# Altium schematic sheet Breathalyzer.SchDoc(Breathalyzer)
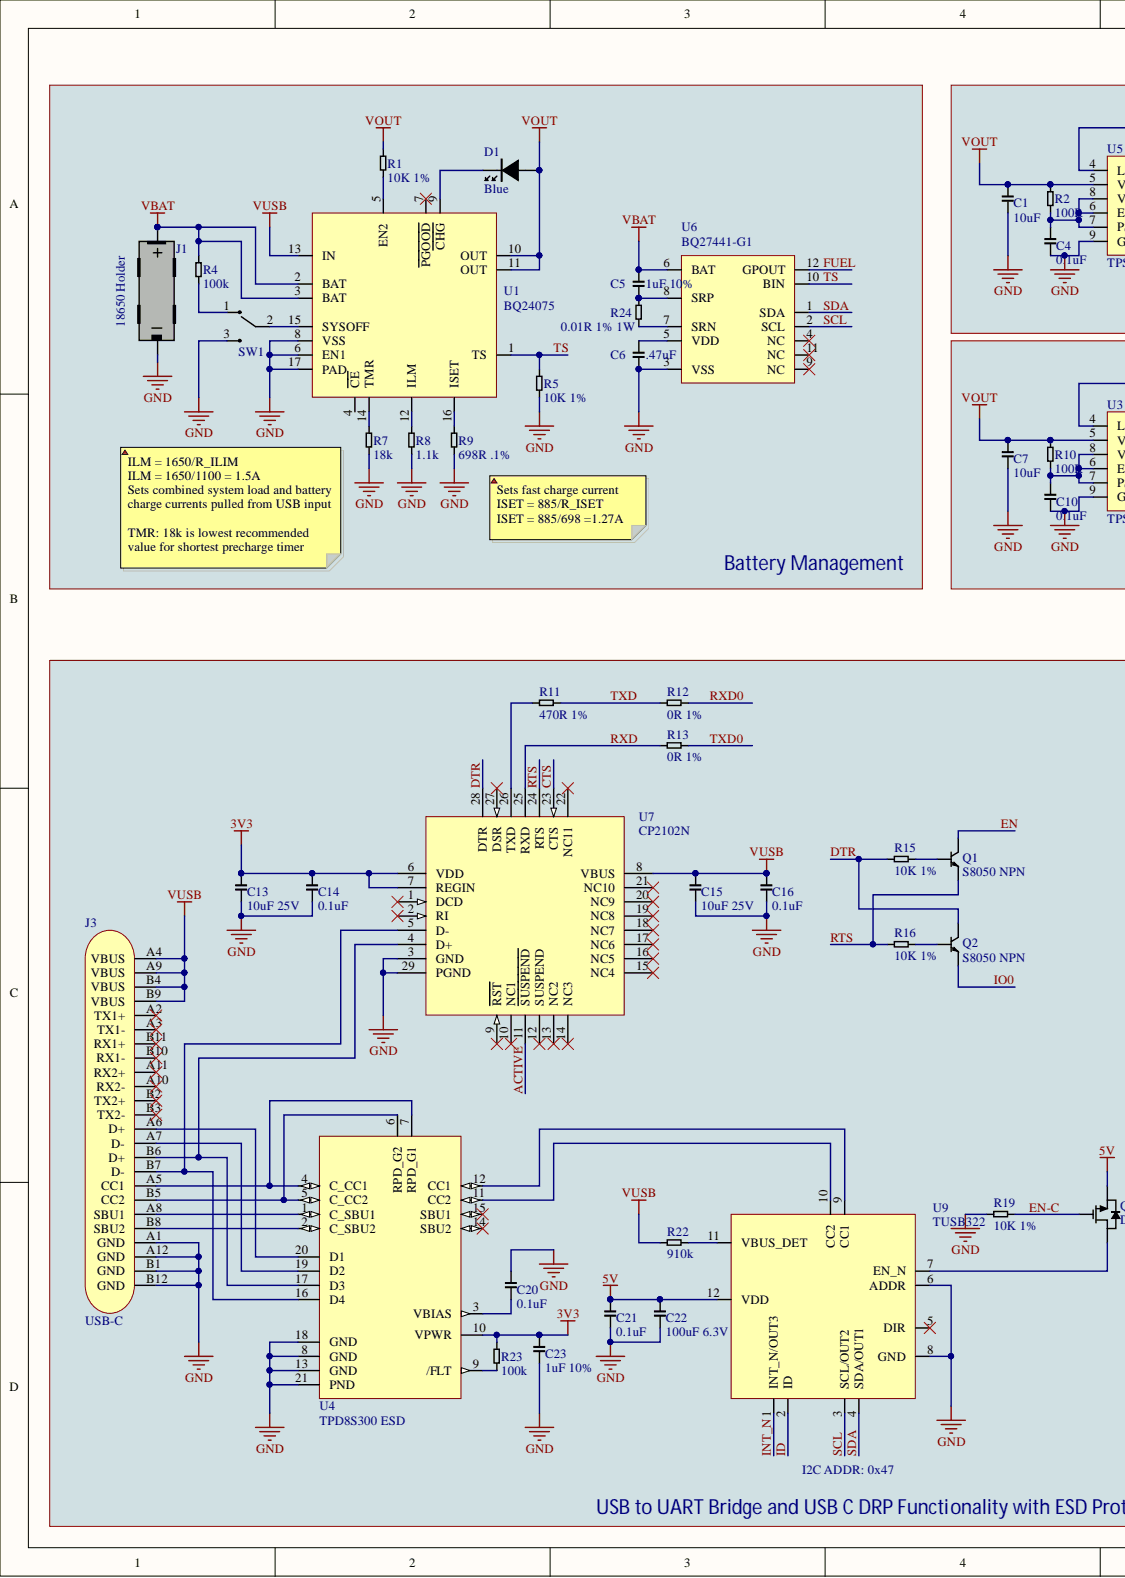
[diagram p0: Breathalyzer.SchDoc, left]
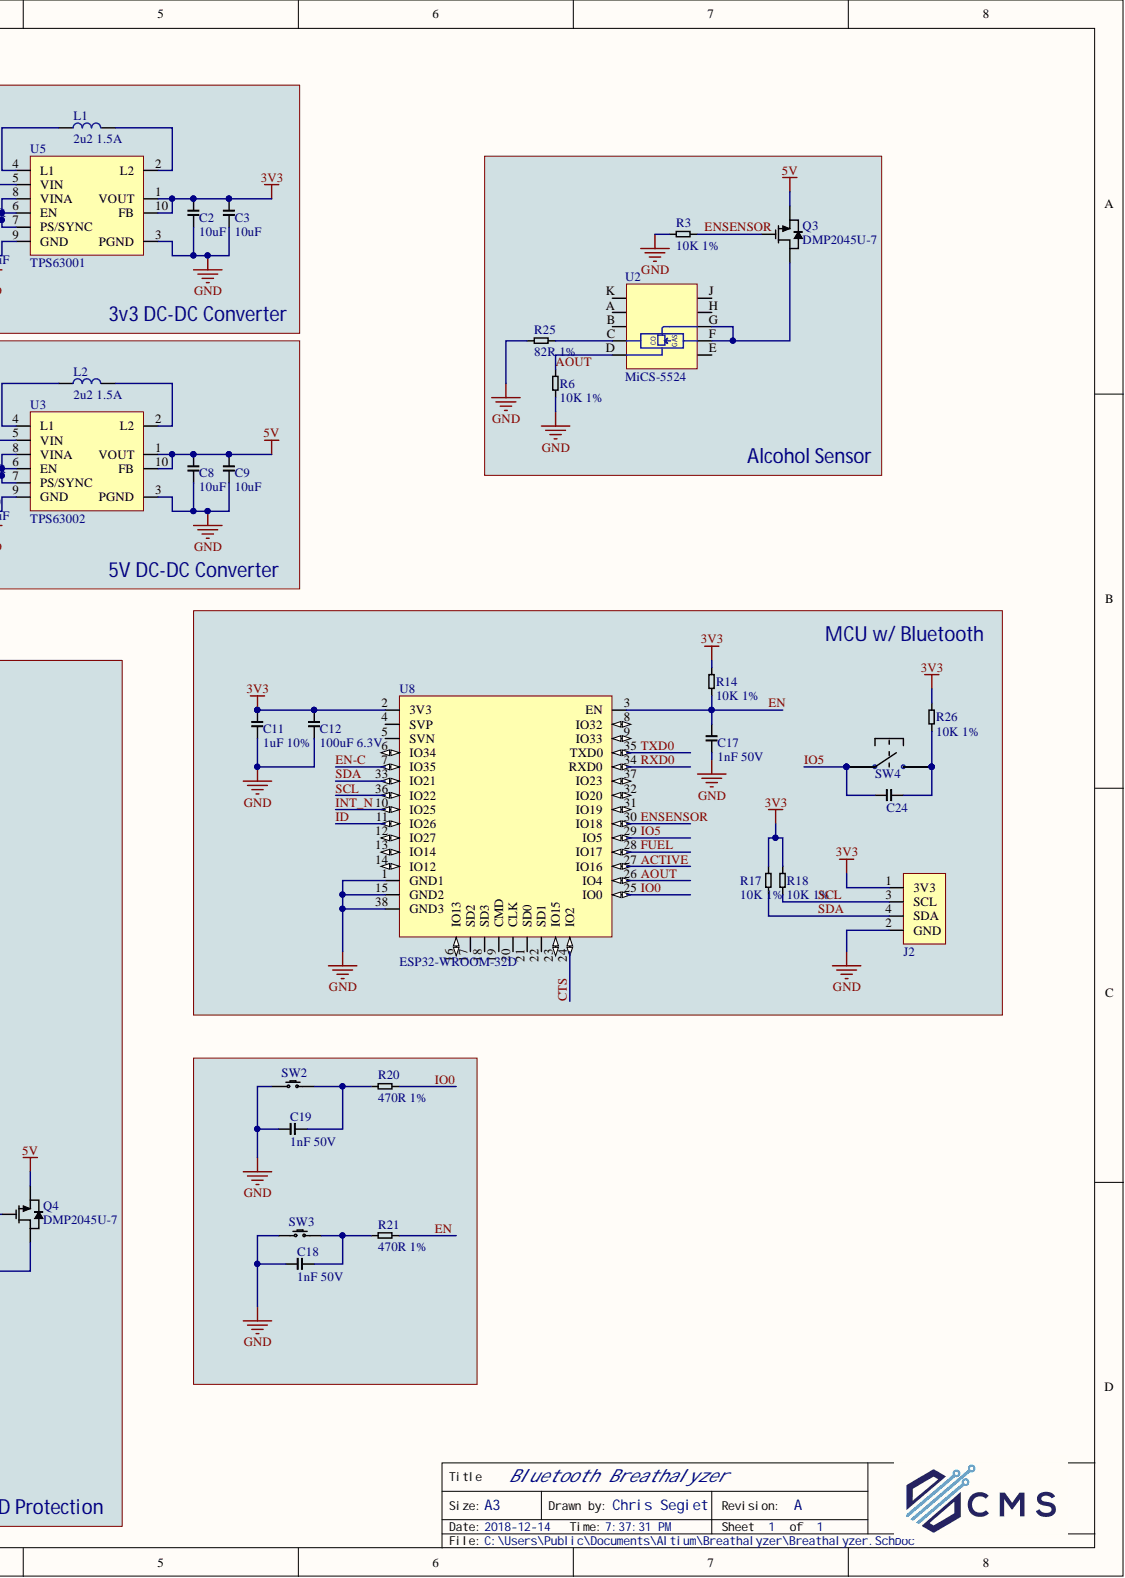
[diagram p1: Breathalyzer.SchDoc, right]

## Parts
C1  10uF  [0805MLCC]
C2  10uF  [0805MLCC]
C3  10uF  [0805MLCC]
C4  0.1uF  [0603MLCC]
C5  1uF 10%  Capacitance: 1uF  [0603MLCC]
C6  .47uF  [0805MLCC]
C7  10uF  [0805MLCC]
C8  10uF  [0805MLCC]
C9  10uF  [0805MLCC]
C10  0.1uF  [0603MLCC]
C11  1uF 10%  Capacitance: 1uF  [0603MLCC]
C12  100uF 6.3V  Capacitance: 100uF  [1206MLCC]
C13  10uF 25V  Capacitance: 10uF  [1206MLCC]
C14  0.1uF  Voltage Rating (DC): 25V  [0603MLCC]
C15  10uF 25V  Capacitance: 10uF  [1206MLCC]
C16  0.1uF  Voltage Rating (DC): 25V  [0603MLCC]
C17  1nF 50V  Voltage Rating (DC): 50V  Capacitance: 1nF  [0603MLCC]
C18  1nF 50V  Voltage Rating (DC): 50V  Capacitance: 1nF  [0603MLCC]
C19  1nF 50V  Voltage Rating (DC): 50V  Capacitance: 1nF  [0603MLCC]
C20  0.1uF  [0603MLCC]
C21  0.1uF  [0603MLCC]
C22  100uF 6.3V  Capacitance: 100uF  [1206MLCC]
C23  1uF 10%  Tolerance: 10%  Capacitance: 1uF  [0603MLCC]
C24  1nF 50V  [0603MLCC]  (value not drawn)
D1  Blue  [LTST-C193TBKT-5A]
J1  18650 Holder  [18650 Holder]
J2  Header for I2C Testing  [1x4 SMD Header]  (value not drawn)
J3  USB-C  [USB-C Receptacle]
L1  2u2 1.5A  Saturation Current: 1.5A  [VLF4012AT-2R2M1R5]
L2  2u2 1.5A  Saturation Current: 1.5A  [VLF4012AT-2R2M1R5]
Q1  S8050 NPN  [SOT23-NPN]
Q2  S8050 NPN  [SOT23-NPN]
Q3  DMP2045U-7  [SOT91P240X110-3N]
Q4  DMP2045U-7  [SOT91P240X110-3N]
R1  10K 1%  [0805RES]
R2  100R  [0805RES]
R3  10K 1%  Tolerance: 1%  [0805RES]
R4  100k  [0603RES]
R5  10K 1%  Tolerance: 1%  [0805RES]
R6  10K 1%  Tolerance: 1%  [0805RES]
R7  18k  [0805RES]
R8  1.1k  [0603RES]
R9  698R .1%  Resistance: 698R  [0805RES]
R10  100R  [0805RES]
R11  470R 1%  Tolerance: 1%  Resistance: 470R  [0805RES]
R12  0R 1%  Tolerance: 1%  [0603RES]
R13  0R 1%  Tolerance: 1%  [0603RES]
R14  10K 1%  Tolerance: 1%  [0805RES]
R15  10K 1%  [0805RES]
R16  10K 1%  [0805RES]
R17  10K 1%  Tolerance: 1%  [0805RES]
R18  10K 1%  Tolerance: 1%  [0805RES]
R19  10K 1%  Tolerance: 1%  [0805RES]
R20  470R 1%  Tolerance: 1%  Resistance: 470R  [0805RES]
R21  470R 1%  Tolerance: 1%  Resistance: 470R  [0805RES]
R22  910k  [0603RES]
R23  100k  [0603RES]
R24  0.01R 1% 1W  Tolerance: 1%  Power Rating: 1W  [1206RES]
R25  82R 1%  Tolerance: 1%  Resistance: 82R  [0805RES]
R26  10K 1%  Tolerance: 1%  [0805RES]
SW1  PCM12SMTR  [SW_PCM12SMTR_PCM12SMTR(Primary)]  (value not drawn)
SW2  SMD Light Touch Switch  [Tactile Switch]  (value not drawn)
SW3  SMD Light Touch Switch  [Tactile Switch]  (value not drawn)
SW4  PTS645SM43SMTR  [PTS645SM43SMTR]  (value not drawn)
U1  BQ24075  [VQFN 16-pin 0.5mm pitch]
U2  MiCS-5524  [MiCS5524 Ethanol Sensor]
U3  TPS63002  [SON50P300X300X100-11N]
U4  TPD8S300 ESD  [QFN40P300X300X80-21N]
U5  TPS63001  [SON50P300X300X100-11N]
U6  BQ27441-G1  [SON40P400X250X100-13N]
U7  CP2102N  [QFN50P500X500X80-29N]
U8  ESP32-WROOM-32D  [MODULE_ESP32-WROOM-32D]
U9  TUSB322  [TUSB322IRWBR]

## Nets
3V3: C2.2 C3.2 C11.2 C12.2 C13.2 C14.2 C23.2 J2.1(3V3) R14.2 R17.2 R18.2 R23.2 R26.2 U4.10(VPWR) U5.1(VOUT) U5.10(FB) U7.6(VDD) U7.7(REGIN) U8.2(3V3)
5V: C8.2 C9.2 C21.2 C22.2 Q3.S Q4.S U3.1(VOUT) U3.10(FB) U9.12(VDD)
GND: C1.2 C2.1 C3.1 C4.1 C6.1 C7.2 C8.1 C9.1 C10.1 C11.1 C12.1 C13.1 C14.1 C15.1 C16.1 C17.1 C18.1 C19.1 C20.2 C21.1 C22.1 C23.1 J1.1 J2.2(GND) J3.A1(GND) J3.A12(GND) J3.B1(GND) J3.B12(GND) R3.2 R5.1 R6.1 R7.1 R8.1 R9.1 R19.2 R25.1 SW1.3 SW2.1 SW3.1 U1.6(EN1) U1.8(VSS) U1.17(PAD) U3.3(PGND) U3.9(GND) U4.8(GND) U4.13(GND) U4.18(GND) U4.21(PND) U5.3(PGND) U5.9(GND) U6.3(VSS) U7.3(GND) U7.29(PGND) U8.1(GND1) U8.15(GND2) U8.38(GND3) U9.6(ADDR) U9.8(GND)
VBAT: C5.2 J1.2 R4.2 U1.2(BAT) U1.3(BAT) U6.6(BAT)
VOUT: C1.1 C7.1 D1.1 R1.2 R2.2 R10.2 U1.10(OUT) U1.11(OUT) U3.5(VIN) U5.5(VIN)
VUSB: C15.2 C16.2 J3.A4(VBUS) J3.A9(VBUS) J3.B4(VBUS) J3.B9(VBUS) R22.1 U1.13(IN) U7.8(VBUS)
ACTIVE: U7.11(SUSPEND) U8.27(IO16)
AOUT: R6.2 U2.D U8.26(IO4)
CTS: U7.23(CTS) U8.24(IO2)
DTR: Q2.C R15.1 U7.28(DTR)
EN: C17.2 Q1.C R14.1 R21.2 U8.3(EN)
EN-C: Q4.G R19.1 U8.7(IO35)
ENSENSOR: Q3.G R3.1 U8.30(IO18)
FUEL: U6.12(GPOUT) U8.28(IO17)
ID: U8.11(IO26) U9.2(ID)
INT_N: U8.10(IO25) U9.1(INT_N/OUT3)
IO0: Q2.E R20.2 U8.25(IO0)
IO5: C24.2 SW4.1 SW4.2 U8.29(IO5)
RTS: Q1.E R16.1 U7.24(RTS)
RXD: R13.1 U7.25(RXD)
RXD0: R12.2 U8.34(RXD0)
SCL: J2.3(SCL) R18.1 U6.2(SCL) U8.36(IO22) U9.3(SCL/OUT2)
SDA: J2.4(SDA) R17.1 U6.1(SDA) U8.33(IO21) U9.4(SDA/OUT1)
TS: R5.2 U1.1(TS) U6.10(BIN)
TXD: R11.2 R12.1
TXD0: R13.2 U8.35(TXD0)
NetC4_2: C4.2 R2.1 U5.6(EN) U5.7(PS/SYNC) U5.8(VINA)
NetC5_1: C5.1 R24.2 U6.8(SRP)
NetC6_2: C6.2 U6.5(VDD)
NetC10_2: C10.2 R10.1 U3.6(EN) U3.7(PS/SYNC) U3.8(VINA)
NetC18_2: C18.2 R21.1 SW3.2
NetC19_2: C19.2 R20.1 SW2.2
NetC20_1: C20.1 U4.3(VBIAS)
NetC24_1: C24.1 R26.1 SW4.3 SW4.4
NetD1_2: D1.2 U1.9(CHG)
NetJ3_A5: J3.A5(CC1) U4.4(C_CC1) U4.7(RPD_G1)
NetJ3_A6: J3.A6(D+) U4.20(D1)
NetJ3_A7: J3.A7(D-) U4.19(D2)
NetJ3_A8: J3.A8(SBU1) U4.1(C_SBU1)
NetJ3_B5: J3.B5(CC2) U4.5(C_CC2) U4.6(RPD_G2)
NetJ3_B6: J3.B6(D+) U4.17(D3) U7.4(D+)
NetJ3_B7: J3.B7(D-) U4.16(D4) U7.5(D-)
NetJ3_B8: J3.B8(SBU2) U4.2(C_SBU2)
NetL1_1: L1.1 U5.4(L1)
NetL1_2: L1.2 U5.2(L2)
NetL2_1: L2.1 U3.4(L1)
NetL2_2: L2.2 U3.2(L2)
NetQ1_B: Q1.B R15.2
NetQ2_B: Q2.B R16.2
NetQ3_D: Q3.D U2.F U2.G
NetQ4_D: Q4.D U9.7(EN_N)
NetR1_1: R1.1 U1.5(EN2)
NetR4_1: R4.1 SW1.1
NetR7_2: R7.2 U1.14(TMR)
NetR8_2: R8.2 U1.12(ILM)
NetR9_2: R9.2 U1.16(ISET)
NetR11_1: R11.1 U7.26(TXD)
NetR22_2: R22.2 U9.11(VBUS_DET)
NetR23_1: R23.1 U4.9(/FLT)
NetR24_1: R24.1 U6.7(SRN)
NetR25_2: R25.2 U2.C
NetSW1_2: SW1.2 U1.15(SYSOFF)
NetU4_11: U4.11(CC2) U9.10(CC2)
NetU4_12: U4.12(CC1) U9.9(CC1)

Not connected: J3 A2(TX1+) A3(TX1-) A10(RX2-) A11(RX2+) B2(TX2+) B3(TX2-) B10(RX1-) B11(RX1+); U1 4(CE) 7(PGOOD); U2 A B E H J K; U4 14(SBU2) 15(SBU1); U6 4(NC) 9(NC) 11(NC); U7 1(DCD) 2(RI) 9(RST) 10(NC1) 12(SUSPEND) 13(NC2) 14(NC3) 15(NC4) 16(NC5) 17(NC6) 18(NC7) 19(NC8) 20(NC9) 21(NC10) 22(NC11) 27(DSR); U8 4(SVP) 5(SVN) 6(IO34) 8(IO32) 9(IO33) 12(IO27) 13(IO14) 14(IO12) 16(IO13) 17(SD2) 18(SD3) 19(CMD) 20(CLK) 21(SD0) 22(SD1) 23(IO15) 31(IO19) 32(IO20) 37(IO23); U9 5(DIR)
